# Altium schematic sheet 4_A9912FH-PCB1-SR_DRIVER_V1.SchDoc(4_A9912FH-PCB1-SR_DRIVER_V1)
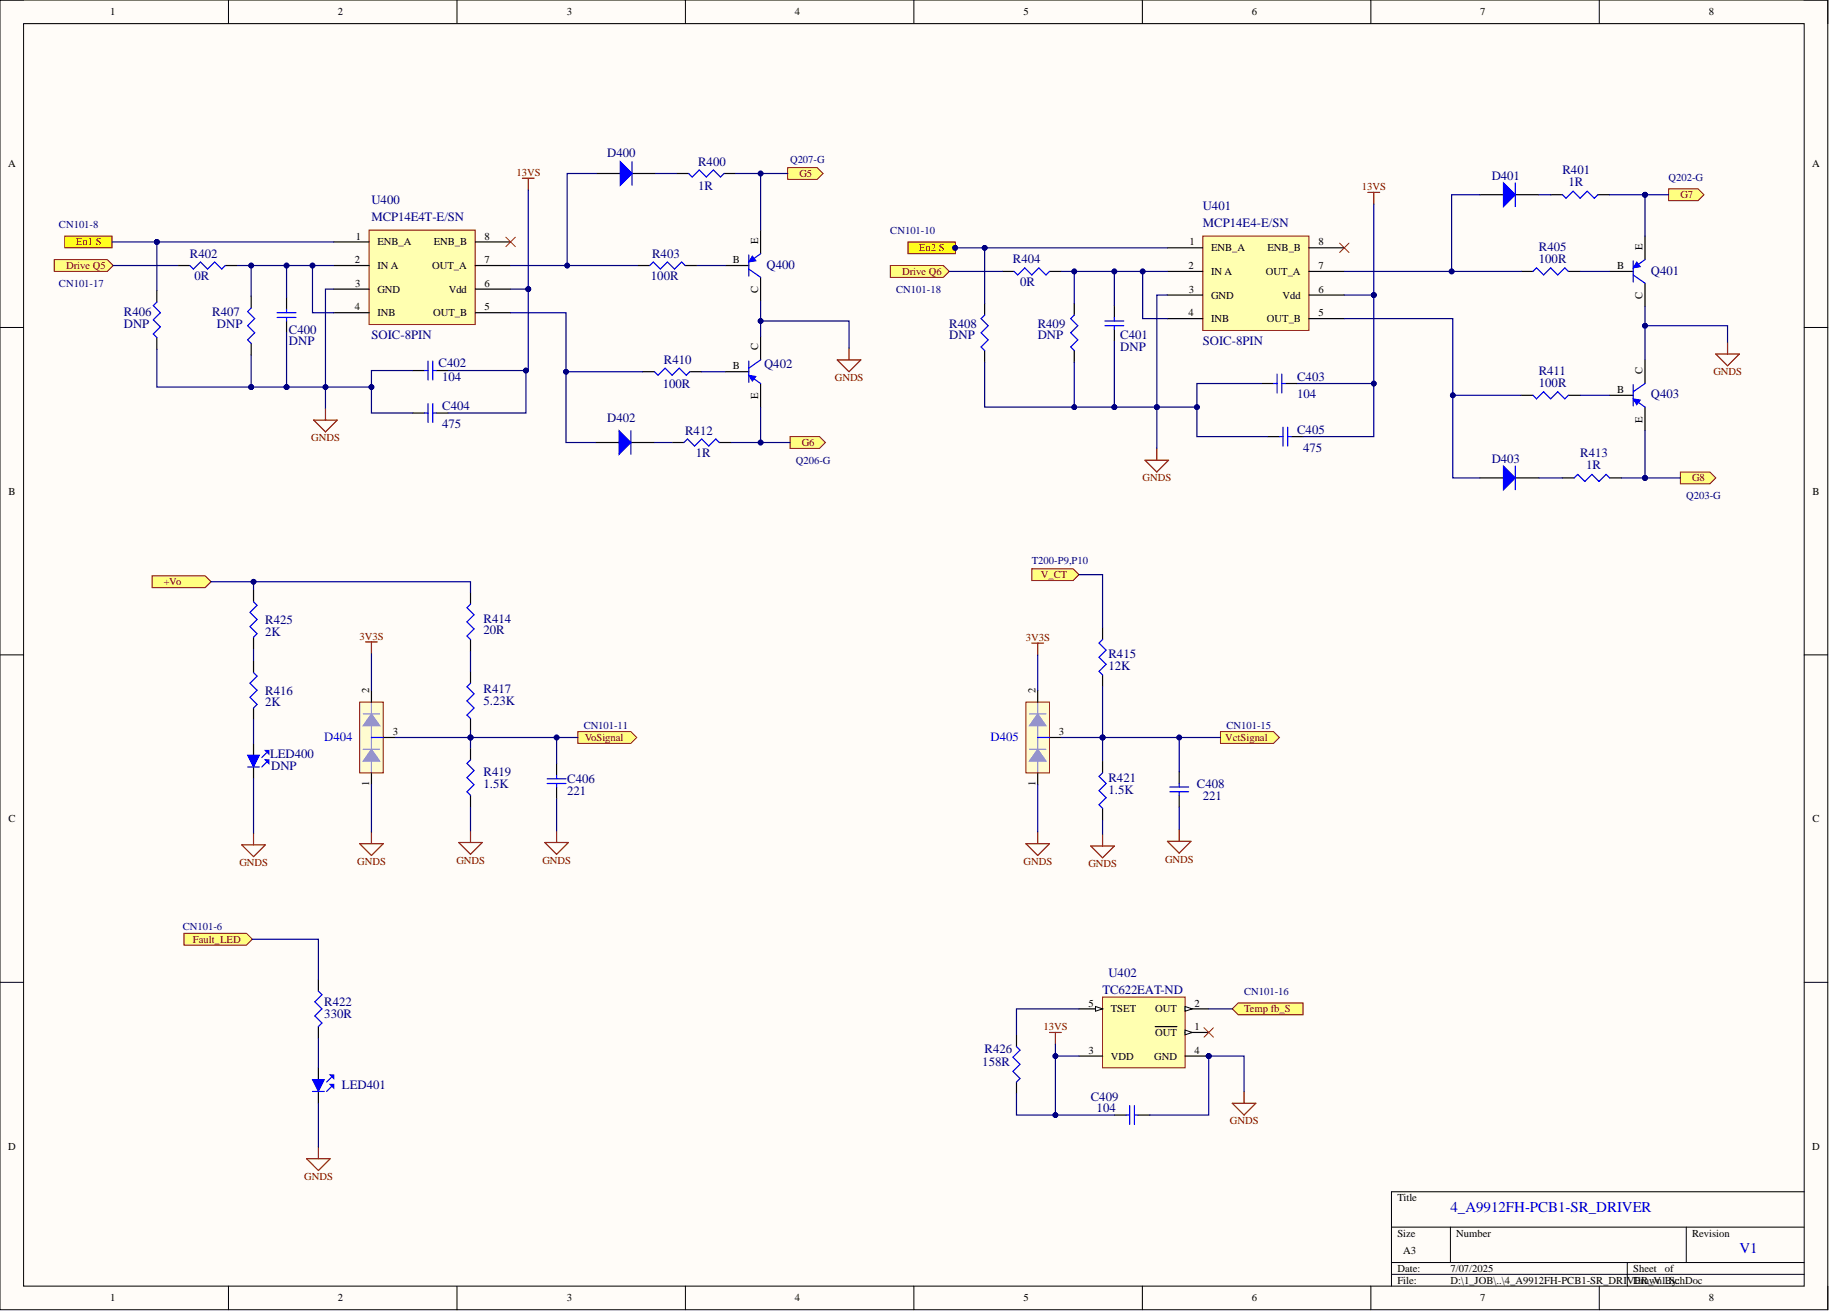
[diagram p0: 4_A9912FH-PCB1-SR_DRIVER_V1.SchDoc]

## Parts
C400  DNP  [CS-0805]
C401  DNP  [CS-0805]
C402  104  [CS-0805]
C403  104  [CS-0805]
C404  value 475  [CS-0805]  (hidden comment: 106)
C405  475  [CS-0805]
C406  221  [CS-0805]
C408  221  [CS-0805]
C409  104  [CS-0805]
D400  MBR0540T1G  [SOD-123]  (value not drawn)
D401  MBR0540T1G  [SOD-123]  (value not drawn)
D402  MBR0540T1G  [SOD-123]  (value not drawn)
D403  MBR0540T1G  [SOD-123]  (value not drawn)
D404  BAS40-40-7-F  [DS-SOT23-MMBD4148]  (value not drawn)
D405  BAS40-40-7-F  [DS-SOT23-MMBD4148]  (value not drawn)
LED400  DNP  [LEDS-HUB35A]
LED401  3.2X1.6X1.1  [LEDS-HUB35A]  (value not drawn)
Q400  FMMT722  [SOT-23-3]  (value not drawn)
Q401  FMMT722  [SOT-23-3]  (value not drawn)
Q402  FMMT722  [SOT-23-3]  (value not drawn)
Q403  FMMT722  [SOT-23-3]  (value not drawn)
R400  1R  [RS-0805]
R401  1R  [RS-0805]
R402  0R  [RS-0805]
R403  100R  [RS-0805]
R404  0R  [RS-0805]
R405  100R  [RS-0805]
R406  DNP  [0603]
R407  DNP  [0603]
R408  DNP  [0603]
R409  DNP  [0603]
R410  100R  [RS-0805]
R411  100R  [RS-0805]
R412  1R  [RS-0805]
R413  1R  [RS-0805]
R414  20R  [RS-1206S]
R415  12K  [RS-0805]
R416  2K  [0603]
R417  5.23K  [RS-1206S]
R419  1.5K  [RS-0805]
R421  1.5K  [RS-0805]
R422  330R  [0603]
R425  2K  [0603]
R426  158R  [RS-0805]
U400  PackageReference: SOIC-8PIN  PartNumber: MCP14E4T-E/SN  [8-SOIC (0.154", 3.90mm Width)]  (hidden comment: MCP14E4_SOIC8)
U401  PackageReference: SOIC-8PIN  PartNumber: MCP14E4-E/SN  [8-SOIC (0.154", 3.90mm Width)]  (hidden comment: MCP14E4_SOIC8)
U402  TC622EAT  Supplier Part Number 1: TC622EAT-ND  [IC-TO220-P1_7-5]

## Ports
+Vo, Drive Q5, Drive Q6, En1 S, En2 S, Fault_LED, G5, G6, G7, G8, Temp fb_S, V_CT, VctSignal, VoSignal

## Nets
3V3S: D404.2 D405.2
13VS: C402.2 C403.2 C404.2 C405.2 C409.2 R426.1 U400.6(Vdd) U401.6(Vdd) U402.3(VDD)
GNDS: C400.1 C401.1 C402.1 C403.1 C404.1 C405.1 C406.1 C408.1 C409.1 D404.1 D405.1 LED400.K LED401.K Q400.C Q401.C Q402.C Q403.C R406.1 R407.1 R408.1 R409.1 R419.1 R421.1 U400.3(GND) U401.3(GND) U402.4(GND)
+Vo: R414.2 R425.2 ; port +Vo
Drive Q5: R402.1 ; port Drive Q5
Drive Q6: R404.1 ; port Drive Q6
En1 S: R406.2 U400.1(ENB_A) ; port En1 S
En2 S: R408.2 U401.1(ENB_A) ; port En2 S
Fault_LED: R422.2 ; port Fault_LED
G5: Q400.E R400.2 ; port G5
G6: Q402.E R412.2 ; port G6
G7: Q401.E R401.2 ; port G7
G8: Q403.E R413.2 ; port G8
Temp fb_S: U402.2(OUT) ; port Temp fb_S
V_CT: R415.2 ; port V_CT
VctSignal: C408.2 D405.3 R415.1 R421.2 ; port VctSignal
VoSignal: C406.2 D404.3 R417.1 R419.2 ; port VoSignal
NetC400_2: C400.2 R402.2 R407.2 U400.2(IN_A) U400.4(INB)
NetC401_2: C401.2 R404.2 R409.2 U401.2(IN_A) U401.4(INB)
NetD400_A: D400.A R403.2 U400.7(OUT_A)
NetD400_K: D400.K R400.1
NetD401_A: D401.A R405.2 U401.7(OUT_A)
NetD401_K: D401.K R401.1
NetD402_A: D402.A R410.2 U400.5(OUT_B)
NetD402_K: D402.K R412.1
NetD403_A: D403.A R411.2 U401.5(OUT_B)
NetD403_K: D403.K R413.1
NetLED400_A: LED400.A R416.1
NetLED401_A: LED401.A R422.1
NetQ400_B: Q400.B R403.1
NetQ401_B: Q401.B R405.1
NetQ402_B: Q402.B R410.1
NetQ403_B: Q403.B R411.1
NetR414_1: R414.1 R417.2
NetR416_2: R416.2 R425.1
NetR426_2: R426.2 U402.5(TSET)

Not connected: U400 8(ENB_B); U401 8(ENB_B); U402 1(OUT)

## Library data of the parts
C400-C406 C408 C409 = Cap Semi: Capacitor (Semiconductor SIM Model); Component Kind: Standard; LatestRevisionDate: 29-May-2009; LatestRevisionNote: IPC-7351 Footprint Added.; Library Name: Miscellaneous Devices.IntLib; PackageDescription: Chip Capacitor; PackageReference: CAP0805; Published: 8-Jun-2000; Signal Integrity: Cap Semi; Simulation: CAP; Publisher: Altium Limited
  C400 C401: Value: DNP
  C402 C403 C409: Value: 104
  C405: Value: 475
  C406 C408: Value: 221
D400-D403 = Diode 1N4001: 1 Amp General Purpose Rectifier; Disti PartNumber: MBR0540T1GOSCT-ND; Description/Value: DIODE SCHOTTKY 40V 0.5A SOD123; Component Kind: Standard; Library Name: Miscellaneous Devices.IntLib; PackageDescription: Name	Type	Description	Vault	Item Revision; PackageReference: SOD_123; PartNumber: MBR0540T1G; Signal Integrity: 1N4001; Simulation: 1N4001
D404 D405 = CMP-004-00019-2: Dual small signal switching diode - SOT23; Alternative accepted: Soft check; PN Egg: A-D-BAV99-SOT23; Value: BAV99; Component Kind: Standard; Termination Style: SMD; Type: Switching; Library Name: Eggtronic Engineering Pro on A365
LED400 LED401 = LED: Component Kind: Standard; Description: LED
Q400-Q403 = PMMT591A: PNP BISS Transistor; Disti PartNumber: FMMT720CT-ND; PartNumber: FMMT720TA; Description/Value: TRANS SW PNP 40V 1.5A SOT23-3; Component Kind: Standard; Library Name: Philips Discrete BJT - Low Power.IntLib; PackageDescription: 3-Pin SOT-23 Package 0.95 mm Pitch, 2.3 mm Lead Span; PackageReference: SOT23 (BCE); Signal Integrity: PMMT591A; Simulation: PMMT591A
R400-R405 R410-R415 R417 R419 R421 R426 = Res3: Resistor; Component Kind: Standard; LatestRevisionDate: 29-May-2009; LatestRevisionNote: IPC-7351 Footprint Added.; Library Name: Miscellaneous Devices.IntLib; PackageDescription: Chip Resistor; PackageReference: RES0805; Published: 8-Jun-2000; Signal Integrity: Res; Simulation: RESISTOR; Publisher: Altium Limited
  R400 R401 R412 R413: Value: 1R
  R402 R404: Value: 0R
  R403 R405 R410 R411: Value: 100R
  R414: Value: 20R
  R415: Value: 12K
  R417: Value: 5.23K
  R419 R421: Value: 1.5K
  R426: Value: 158R
R406-R409 R416 R422 R425 = CRCW060310K0FKEA: Res, Thick Film, 0603, 10K, 1% 1/10watt; Database Table Name: Resistor; Power Rating: 1/10W; Temperature Coefficient: ±100PPM/K; Voltage Rating: 75V; Component Kind: Standard; Component Type: Standard; ComponentLink1Description: Vishay; ComponentLink1URL: http://www.vishay.com/docs/20035/dcrcwe3.pdf; Library Name: Component_Lib.DbLib; Part Number: CRCW060310K0FKEA; Pin Count: 2; Published: 7/29/2011; Simulation: RES; Supplier 1: Digi-Key; Supplier 2: Mouser; Supplier Part Number 1: 541-10.0KSCT-ND; Supplier Part Number 2: RES0805; Termination Style: SMD; Tolerance: 1%; Publisher: Todd Perrodin
  R406 R408: Operating Temperature Ran: -55°C to +155°C; Value: 10K
  R407 R409: Operating Temperature Ran: -55°C to +155°C; Value: DNP
  R416 R425: Operating Temperature Range: -55°C to +155°C; Value: 2K
  R422: Operating Temperature Range: -55°C to +155°C; Value: 330R
U400 U401 = MCP14E4_SOIC8: Disti PartNumber: MCP14E4-E/SN-ND; Description/Value: IC MOSFET DVR 4.0A DUAL 8SOIC; Component Kind: Standard; Library Name: SNM_Comp.SchLib
U402 = TC622EAT: Low Cost Single Trip Point Temperature Sensor, 5-Pin TO-220, Industrial Temperature; Component Kind: Standard; ComponentLink1URL: http://ww1.microchip.com/downloads/en/DeviceDoc/21440C.pdf; ComponentLink2Description: Packaging Specification; ComponentLink2URL: http://www.microchip.com/stellent/groups/techpub_sg/documents/packagingspec/en012702.pdf; ComponentLink3Description: Microchip.com Home; ComponentLink3URL: http://www.microchip.com/; Library Name: Microchip Thermal Management.IntLib; PackageDescription: 5-Lead Plastic Transistor Outline (AT) [TO-220]; PackageReference: TO-220-AT5; Supplier 1: Digi-Key
